# Altium schematic sheet ETRX_Shield.SchDoc
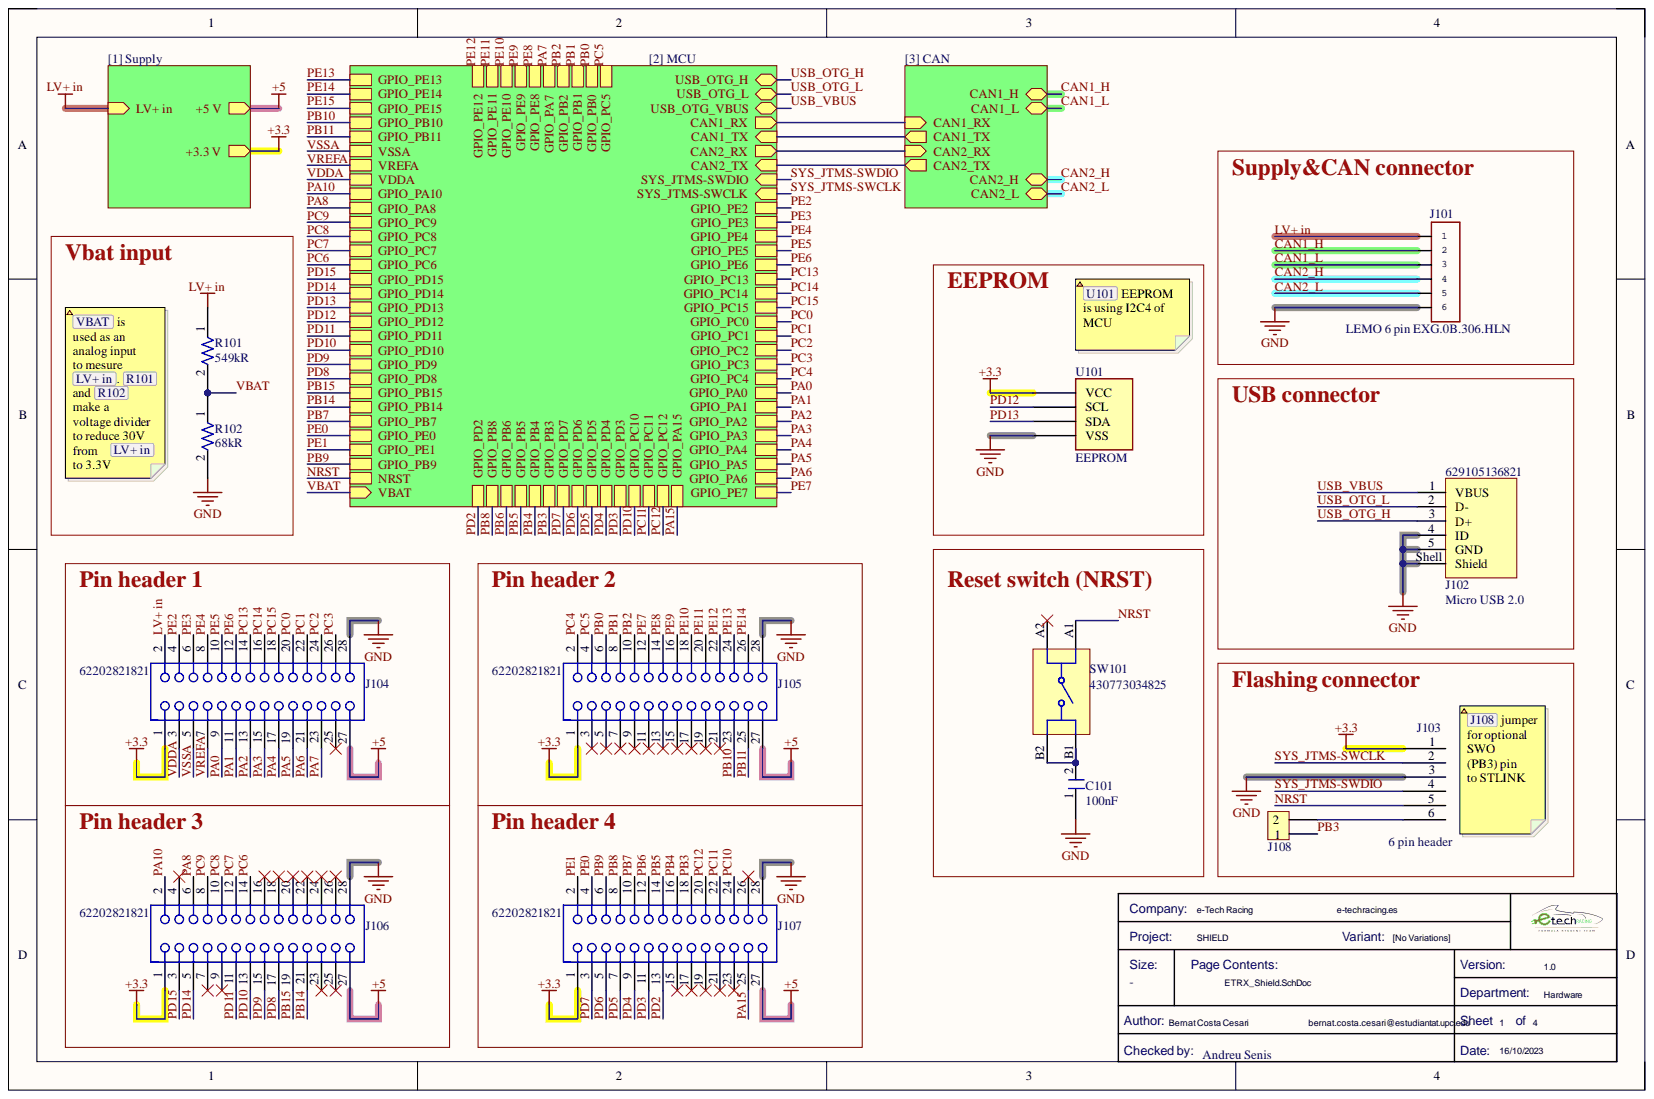
[diagram p0: ETRX_Shield.SchDoc]

## Parts
C101  Capacitance: 100nF  [C1206FNPC-RW]  (hidden comment: 885012208058)
J101  LEMO 6 pin EXG.0B.306.HLN  [EXG.0B.306.HLN]
J102  629105136821  Application: Micro USB 2.0  [629105136821]
J103  6 pin header
J104  62202821821  [62202821821]
J105  62202821821  [62202821821]
J106  62202821821  [62202821821]
J107  62202821821  [62202821821]
J108  282836-2  [J_screw_1x2]  (value not drawn)
R101  549kR
R102  Resistance: 68kR  [R1206FTK-W]  (hidden comment: CR1206AFX-6802EAS)
SW101  430773034825  [WS-TASV_L6W6H3.4_4307x30348xx]
U101  EEPROM

## Nets
+3.3: J103.1 J104.1 J105.1 J106.1 J107.1 U101.1(VCC)
+5: J104.27 J105.27 J106.27 J107.27
GND: C101.1 J101.6 J102.4(ID) J102.5(GND) J102.Shell(Shield) J103.3 J104.28 J105.28 J106.28 J107.28 R102.2 U101.4(VSS)
CAN1_H: J101.2
CAN1_L: J101.3
CAN2_H: J101.4
CAN2_L: J101.5
LV+ in: J101.1 J104.2 R101.1
NRST: J103.5 SW101.A1
PA0: J104.9
PA1: J104.11
PA2: J104.13
PA3: J104.15
PA4: J104.17
PA5: J104.19
PA6: J104.21
PA7: J104.23
PA8: J106.6
PA10: J106.2
PA15: J107.25
PB0: J105.6
PB1: J105.8
PB2: J105.10
PB3: J107.18 J108.1
PB4: J107.16
PB5: J107.14
PB6: J107.12
PB7: J107.10
PB8: J107.8
PB9: J107.6
PB10: J105.23
PB11: J105.25
PB14: J106.21
PB15: J106.19
PC0: J104.20
PC1: J104.22
PC2: J104.24
PC3: J104.26
PC4: J105.2
PC5: J105.4
PC6: J106.14
PC7: J106.12
PC8: J106.10
PC9: J106.8
PC10: J107.24
PC11: J107.22
PC12: J107.20
PC13: J104.14
PC14: J104.16
PC15: J104.18
PD2: J107.13
PD3: J107.11
PD4: J107.9
PD5: J107.7
PD6: J107.5
PD7: J107.3
PD8: J106.17
PD9: J106.15
PD10: J106.13
PD11: J106.11
PD12: U101.2(SCL)
PD13: U101.3(SDA)
PD14: J106.5
PD15: J106.3
PE0: J107.4
PE1: J107.2
PE2: J104.4
PE3: J104.6
PE4: J104.8
PE5: J104.10
PE6: J104.12
PE7: J105.12
PE8: J105.14
PE9: J105.16
PE10: J105.18
PE11: J105.20
PE12: J105.22
PE13: J105.24
PE14: J105.26
SYS_JTMS-SWCLK: J103.2
SYS_JTMS-SWDIO: J103.4
USB_OTG_H: J102.3(D+)
USB_OTG_L: J102.2(D-)
USB_VBUS: J102.1(VBUS)
VBAT: R101.2 R102.1
VDDA: J104.3
VREFA: J104.7
VSSA: J104.5
NetC101_2: C101.2 SW101.B1 SW101.B2
NetJ103_6: J103.6 J108.2
NetJ104_25: J104.25
NetJ105_3: J105.3
NetJ105_5: J105.5
NetJ105_7: J105.7
NetJ105_9: J105.9
NetJ105_11: J105.11
NetJ105_13: J105.13
NetJ105_15: J105.15
NetJ105_17: J105.17
NetJ105_19: J105.19
NetJ105_21: J105.21
NetJ106_4: J106.4
NetJ106_7: J106.7
NetJ106_9: J106.9
NetJ106_16: J106.16
NetJ106_18: J106.18
NetJ106_20: J106.20
NetJ106_22: J106.22
NetJ106_23: J106.23
NetJ106_24: J106.24
NetJ106_25: J106.25
NetJ106_26: J106.26
NetJ107_15: J107.15
NetJ107_17: J107.17
NetJ107_19: J107.19
NetJ107_21: J107.21
NetJ107_23: J107.23
NetJ107_26: J107.26
NetSW101_A2: SW101.A2
CAN1_RX:
CAN1_TX:
CAN2_RX:
CAN2_TX:
PE15:

## Library data of the parts
C101 = C100n-25V-1206FNPC-X7R: Cap Cer 0.1UF 25V X7R 1206; Manufacturer: Wurth Electronics; .Creator: DO; Tolerance: 10%; Case Code (Imperial): 1206; Case Code (Metric): 3216; Case/Package: 1206; Category: Capacitor; Component Kind: Standard; ComponentLink1Description: Datasheet; ComponentLink1URL: https://www.we-online.com/catalog/datasheet/885012208058.pdf; ComponentLink2Description: Where buy it; ComponentLink2URL: https://www.we-online.com/catalog/en/WCAP-CSGP-25VDC#885012208058; ComponentLink3Description: https://katalog.we-online.de/pbs/datasheet/885012208058.pdf?src-supplier=Digi-Key; ComponentLink3URL: https://katalog.we-online.de/pbs/datasheet/885012208058.pdf?src-supplier=Digi-Key; Length: 0.126inch; Library Name: Passives.SchLib; Manufacturer Part Number: 885012208058; Max Operating Temperature: 125°C; Min Operating Temperature: -55°C; Mount: Surface Mount; Packaging: Tape and Reel; Pricing 1: 1=0,11000, 10=0,10100, 100=0,09100, 500=0,08000 (USD); RoHS: Yes; RoHS Compliant: Yes; Stock 1: 4375; Supplier 1: Digi-Key; Supplier Part Number 1: 732-8109-1-ND; Thickness: 0.035inch; Voltage Rating: 25V; Width: 0.063inch
J101 = EXG.0B.306.HLN: Component Kind: Standard; Library Name: LEMO-EXG.0B.306.HLN.IntLib; MPN: EXG.0B.306.HLN; OC_FARNELL: unknown; OC_NEWARK: unknown
J102 = 629105136821: WR-COM Micro USB 2.0 SMT Type B Horizontal 5 Contacts; Manufacturer: Wurth Elektronik; Gender: Receptacle; Interface Type: Type B; Match Code: WR-USB; Number of Ports: 1; Rated Current: 1A; Working Voltage: 30V (AC); Category: Input/Output Connectors; Component Kind: Standard; ComponentLink1Description: Website Link; ComponentLink1URL: https://www.we-online.com/redexpert/article/629105136821?ad; ComponentLink2Description: Datasheet Link; ComponentLink2URL: https://www.we-online.com/redexpert/spec/629105136821?ad; Library Name: WR-USB.IntLib; Manufacturer Part Number: 629105136821; Mount: Surface Mount; Number of Pins: 5; Operating Temperature Max: 105°C; Operating Temperature Min: -40°C; Orientation: Horizontal; Packaging: Tape and Reel
J104-J107 = 62202821821: WR-PHD Socket Header, THT, Vertical, pitch 1.27mm, 2 Row, 28P; Manufacturer: Wurth Elektronik; Contact Resistance: 20mΩ; Rated Current: 1A; Withstanding Voltage: 500V; Working Voltage: 250V; Category: Board-to-Board Connectors; Component Kind: Standard; ComponentLink1Description: Website Link; ComponentLink1URL: https://www.we-online.com/redexpert/article/62202821821?ad; ComponentLink2Description: Datasheet Link; ComponentLink2URL: https://www.we-online.com/redexpert/spec/62202821821?ad; Gender: Socket Header; Library Name: Altium_WR-PHD (rev22b).IntLib; Manufacturer Part Number: 62202821821; Match Code: WR-PHD; Mount: Through Hole; Number of Pins: 28; Number of Rows: 2; Operating Temperature Max: 105°C; Operating Temperature Min: -40°C; Orientation: Vertical; Packaging: Tube; Pitch: 1.27mm
J108 = J_CON2x1: Connector, Terminal Block; Wire Receptacle; 2 Pos.; 16-30 AWG; 0.197 in. CL; Sid; Manufacturer 1: TE Connectivity; Category: Terminal Blocks; Component Kind: Standard; ComponentLink1Description: https://datasheet.ciiva.com/15169/getdatasheetpartid-155855-15169553.pdf?src-supplier=Verical; ComponentLink1URL: https://datasheet.ciiva.com/15169/getdatasheetpartid-155855-15169553.pdf?src-supplier=Verical; ComponentLink2Description: https://datasheet.ciiva.com/16393/282836-2-16393382.pdf?src-supplier=Future+Electronics; ComponentLink2URL: https://datasheet.ciiva.com/16393/282836-2-16393382.pdf?src-supplier=Future+Electronics; ComponentLink3Description: https://datasheet.ciiva.com/11757/0900766b8002dc8a-11757385.pdf?src-supplier=RSComponents; ComponentLink3URL: https://datasheet.ciiva.com/11757/0900766b8002dc8a-11757385.pdf?src-supplier=RSComponents; ComponentLink4Description: https://datasheet.ciiva.com/7628/1731782-7628513.pdf?src-supplier=Element14; ComponentLink4URL: https://datasheet.ciiva.com/7628/1731782-7628513.pdf?src-supplier=Element14; ComponentLink5Description: https://datasheet.ciiva.com/823/eng-cd-282836-h10-823437.pdf?src-supplier=Digi-Key; ComponentLink5URL: https://datasheet.ciiva.com/823/eng-cd-282836-h10-823437.pdf?src-supplier=Digi-Key; ComponentLink6Description: https://datasheet.ciiva.com/3141/eng-cs-1308389-eurostyle-terminal-blocks-0607-3141118.pdf?src-supplier=Avnet; ComponentLink6URL: https://datasheet.ciiva.com/3141/eng-cs-1308389-eurostyle-terminal-blocks-0607-3141118.pdf?src-supplier=Avnet; ComponentLink7Description: https://datasheet.ciiva.com/30261/eng-cs-1308389-eurostyle-terminal-blocks-0607-30261813.pdf?src-supplier=Onlinecomponents.com; ComponentLink7URL: https://datasheet.ciiva.com/30261/eng-cs-1308389-eurostyle-terminal-blocks-0607-30261813.pdf?src-supplier=Onlinecomponents.com; Library Name: Electromechanical.SchLib; Manufacturer Part Number 1: 282836-2; Pricing 1: 1=0,41710, 10=0,36230, 100=0,30770, 500=0,29220, 1000=0,26130, 2500=0,23640, 5000=0,21140, 10000=0,20690, 25000=0,20320 (USD); RoHS: Yes; Stock 1: 8391; Supplier 1: Arrow Electronics; Supplier Part Number 1: 282836-2
R101 = R549k - 0805: Component Kind: Standard; Library Name: Passives.SchLib
R102 = R68k-1206FTK: Resistor, AS 1206 68k1% 250mW TC100; Manufacturer: Bourns; .Creator: DO; Category: Chip SMD Resistors; Component Kind: Standard; ComponentLink1Description: Where buy it; ComponentLink1URL: https://www.mouser.es/ProductDetail/Bourns/CR1206AFX-6802EAS?qs=PzGy0jfpSMtMA5jyzS7M7w%3D%3D; ComponentLink2Description: Datasheet; ComponentLink2URL: https://www.mouser.es/datasheet/2/54/cr_a_as-1858644.pdf; Library Name: Passives.SchLib; Manufacturer Part Number: CR1206AFX-6802EAS; Pricing 1: 1=0,01100, 25=0,01100, 50=0,01100, 100=0,01100, 250=0,01100, 500=0,01100 (USD); RoHS: Yes; Stock 1: 4700; Supplier 1: Newark; Supplier Part Number 1: 16AH0632
SW101 = 430773034825: WS-TASV J-Hook SMT Hermetic Tact Switch, L6 x W6 x H3.4mm, 250g, SPST-NO, 12V, 50mA; Manufacturer: Wurth Elektronik; Washable: Yes; Category: Tact Switches; Circuit: SPST-NO; Component Kind: Standard; ComponentLink1Description: Website Link; ComponentLink1URL: https://www.we-online.com/redexpert/article/430773034825?ad; ComponentLink2Description: Datasheet Link; ComponentLink2URL: https://www.we-online.com/redexpert/spec/430773034825?ad; Contact Material: Stainless Steel; Contact Plating: Silver; Height: 3.4mm; Length: 6mm; Manufacturer Part Number: 430773034825; Match Code: WS-TASV; Mount: Surface Mount; Operating Temperature Max: 85°C; Operating Temperature Min: -40°C; Operation Force: 250g; Packaging: Tape and Reel; Rated Current: 50mA; Rated Voltage (DC): 12V; Terminal Material: Copper Alloy; Terminal Plating: Silver; Width: 6mm; Library Name: Altium_WS-SW bo.IntLib
U101 = I2C_EEPROM: Component Kind: Standard; Library Name: CAN Transceiver.SchLib
J103 = 6 pin header: Component Kind: Standard; Library Name: CAN Transceiver.SchLib
